# Altium schematic sheet [07] - LOG.SchDoc("[07] - LOG")
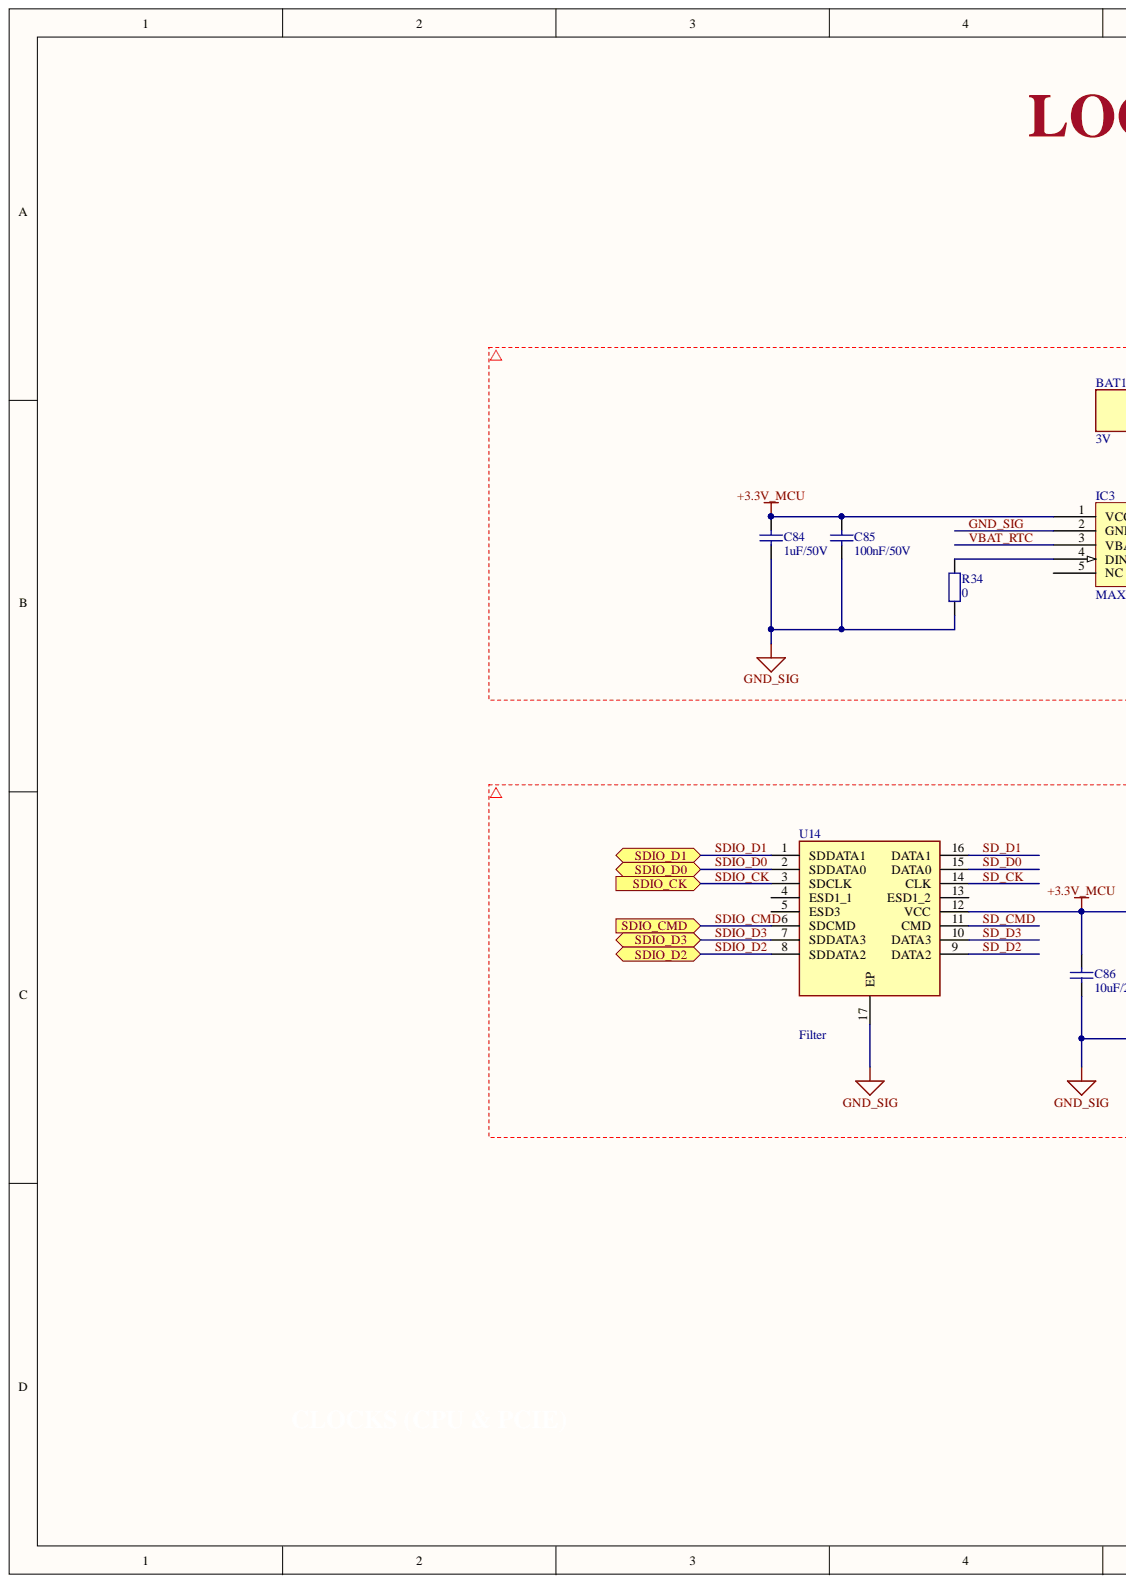
[diagram p0: [07] - LOG.SchDoc, left]
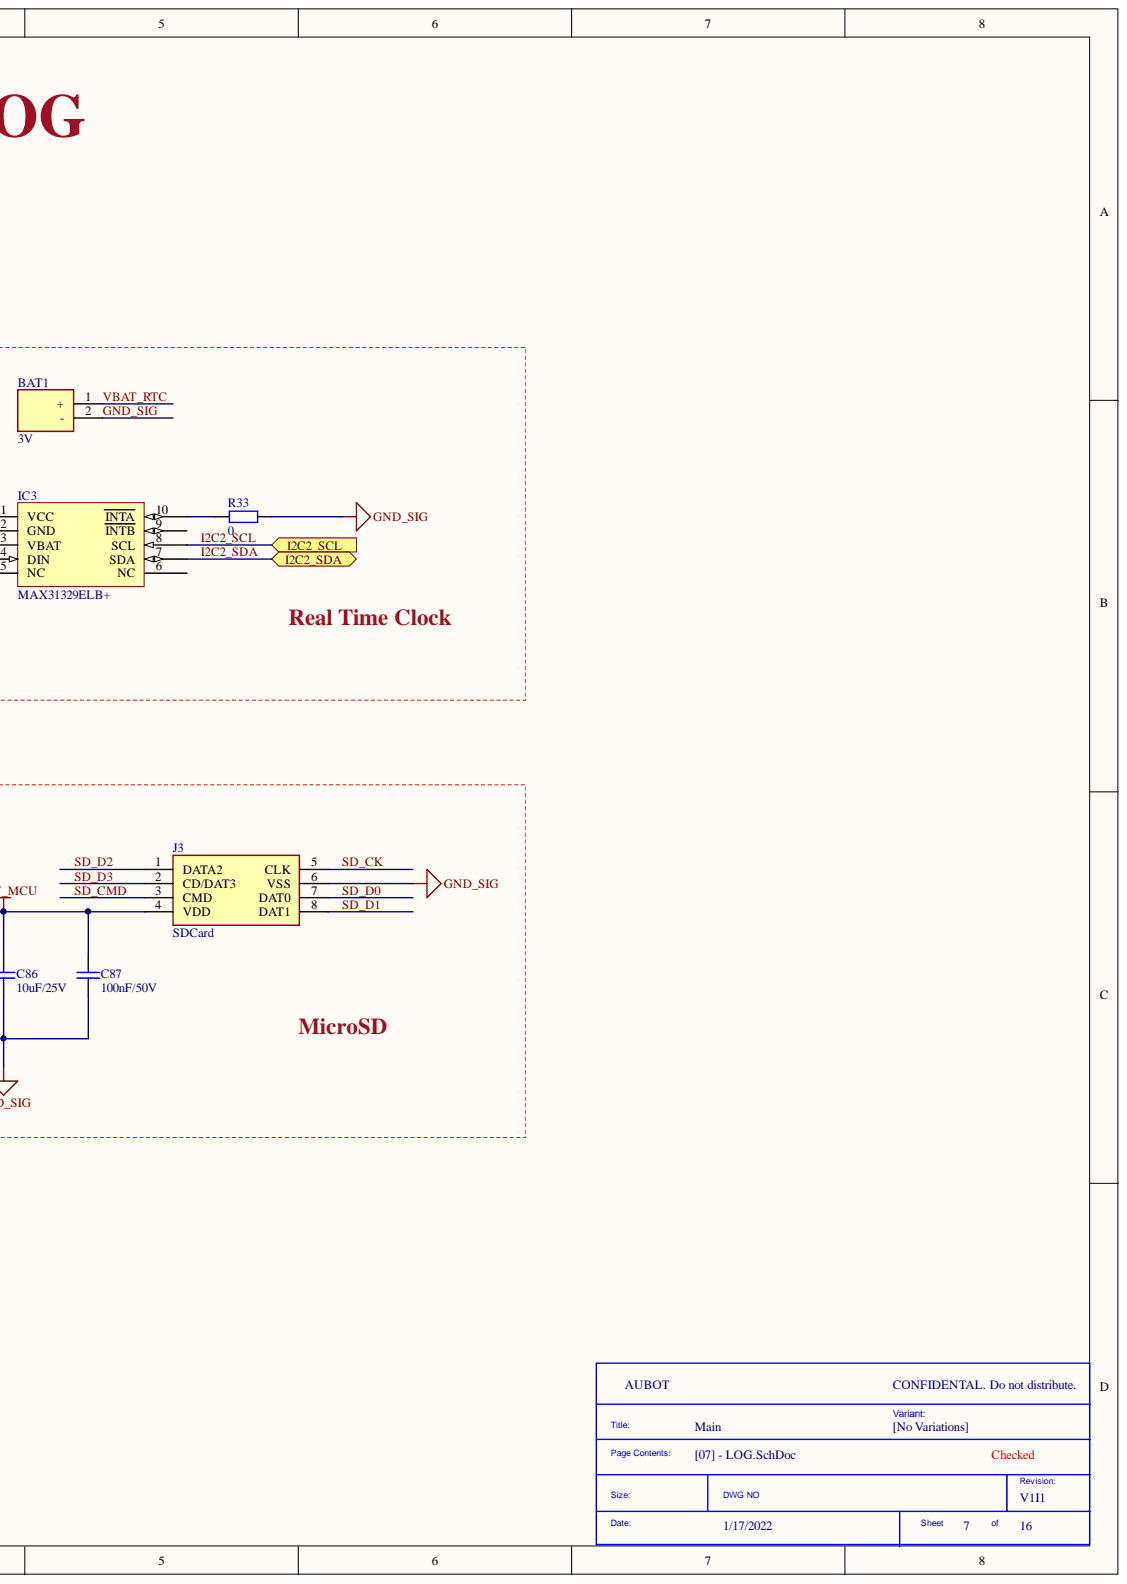
[diagram p1: [07] - LOG.SchDoc, right]

## Parts
BAT1  3V  [SMT CR1220]
C84  1uF/50V  [CAP 0805]
C85  100nF/50V  [CAP 0805]
C86  10uF/25V  [CAP 0805]
C87  100nF/50V  [CAP 0805]
IC3  MAX31329ELB+  [L1055M+2C]
J3  SDCard  [SDCard 8P]
R33  0  [RES 0805]
R34  0  [RES 0805]
U14  Filter  [UDFN-16]

## Ports
I2C2_SCL, I2C2_SDA, SDIO_CK, SDIO_CMD, SDIO_D0, SDIO_D1, SDIO_D2, SDIO_D3

## Nets
+3.3V_MCU: C84.2 C85.2 C86.2 C87.2 IC3.1(VCC) J3.4(VDD) U14.12(VCC)
GND_SIG: BAT1.2 C84.1 C85.1 C86.1 C87.1 IC3.2(GND) J3.6(VSS) R33.2 R34.1 U14.17(EP)
I2C2_SCL: IC3.8(SCL) ; port I2C2_SCL
I2C2_SDA: IC3.7(SDA) ; port I2C2_SDA
SD_CK: J3.5(CLK) U14.14(CLK)
SD_CMD: J3.3(CMD) U14.11(CMD)
SD_D0: J3.7(DAT0) U14.15(DATA0)
SD_D1: J3.8(DAT1) U14.16(DATA1)
SD_D2: J3.1(DATA2) U14.9(DATA2)
SD_D3: J3.2(CD/DAT3) U14.10(DATA3)
SDIO_CK: U14.3(SDCLK) ; port SDIO_CK
SDIO_CMD: U14.6(SDCMD) ; port SDIO_CMD
SDIO_D0: U14.2(SDDATA0) ; port SDIO_D0
SDIO_D1: U14.1(SDDATA1) ; port SDIO_D1
SDIO_D2: U14.8(SDDATA2) ; port SDIO_D2
SDIO_D3: U14.7(SDDATA3) ; port SDIO_D3
VBAT_RTC: BAT1.1 IC3.3(VBAT)
NetIC3_4: IC3.4(DIN) R34.2
NetIC3_10: IC3.10(INTA) R33.1

Not connected: IC3 5(NC) 6(NC) 9(INTB); U14 4(ESD1_1) 5(ESD3) 13(ESD1_2)

## Library data of the parts
BAT1 = Battery 3V: EZ-Coin Cell Battery Retainer, Horizontal SMT, for CR1220 Coin Cell Batteries; Manufacturer Part Number: S8411-45R; Manufacturer: Harwin; Number of Cells: 1; Termination: SMD/SMT; Supplier Part Number 1: 855-S8411-45R; Supplier 1: Mouser; Stock 1: 5083; RoHS: Yes; REACH SVHC: No SVHC; Pricing 1: 1 = 1.52000, 10 = 1.20000, 50 = 1.20000, 100 = 1.02000, 500 = 0.93100, 1000 = 0.85400, 2500 = 0.77500, 5000 = 0.71000, 10000 = 0.70500, 25000 = 0.66500 (USD); Packaging: Tape and Reel; Package Quantity: 500; Number of Batteries: 1; Mount: Surface Mount,PCB; Min Operating Temperature: -55°C; Max Operating Temperature: 105°C; Material: Polyphenylene; Contact Plating: Bronze; ComponentLink2URL: https://datasheet.ciiva.com/1391/1633586-1391067.pdf?src-supplier=Element14; ComponentLink2Description: https://datasheet.ciiva.com/1391/1633586-1391067.pdf?src-supplier=Element14; ComponentLink1URL: https://datasheet.ciiva.com/5224/s8411r-51460-5224679.pdf?src-supplier=Mouser; ComponentLink1Description: https://datasheet.ciiva.com/5224/s8411r-51460-5224679.pdf?src-supplier=Mouser; Component Kind: Standard; Case/Package: SMD/SMT; Library Name: Hieu_Lib.IntLib
C84 = Cap 0805 1uF/50V: 0805 1 uF 50 V ±10 % Tolerance X7R SMT Multilayer Ceramic Capacitor; Manufacturer Part Number: CC0805KKX7R9BB105; Manufacturer: Yageo; Voltage Rating (DC): 50V; Tolerance: 10%; Capacitance: 1uF; Width: 0.049inch; Weight: 0.000194oz; Voltage Rating: 50V; Thickness: 0.057inch; Termination: SMD/SMT; Supplier Part Number 1: 311-1886-1-ND; Supplier 1: Digi-Key; Stock 1: 174238; Series: CC; RoHS Compliant: Yes; RoHS: Yes; Resistor Type: Ceramic; Radiation Hardening: No; Pricing 1: 1 = 0.26000, 10 = 0.17900, 50 = 0.11920, 100 = 0.10130, 500 = 0.07152, 1000 = 0.06257 (USD); Packaging: Tape and Reel; Mount: Surface Mount; Min Operating Temperature: -55°C; Max Operating Temperature: 125°C; Length: 2mm; Lead Free: Lead Free; Height: 1.25mm; Halogen Free: Halogen Free; Dielectric: X7R; Depth: 1.25mm; Construction: Flat; ComponentLink1URL: https://datasheet.ciiva.com/13209/upy-gphc-x7r-6-3v-to-50v-8-12304-13209375.pdf?src-supplier=Mouser; ComponentLink1Description: https://datasheet.ciiva.com/13209/upy-gphc-x7r-6-3v-to-50v-8-12304-13209375.pdf?src-supplier=Mouser; Component Kind: Standard; Case Code (Metric): 2012; Case Code (Imperial): 0805; Case/Package: 0805; Library Name: Hieu_Lib.IntLib
C85 C87 = Cap 0805 100nF/50V: Ceramic capacitor SMD-0805 100nF /50V X7R# K +-10%, Samsung, RoHS; Manufacturer Part Number: CL21B104KBCNNNC; Voltage Rating (DC): 50V; Tolerance: 10%; Dielectric: X7R; Width: 0.049inch; Voltage Rating: 50V; Voltage: 50V; Thickness: 0.037inch; Supplier Part Number 1: C1711; Supplier 1: LCSC; Stock 1: 447650; Series: CL; RoHS Compliant: Yes; RoHS: Yes; Radiation Hardening: No; Pricing 1: 50 = 0.04090, 500 = 0.03130, 1500 = 0.02890, 5000 = 0.02580, 25000 = 0.02430, 50000 = 0.02410, 50 = 0.03950, 500 = 0.03020, 1500 = 0.02790, 5000 = 0.02490, 25000 = 0.02350, 50000 = 0.02330, 50 = 0.20680, 500 = 0.15820, 1500 = 0.14600, 5000 = 0.13020, 25000 = 0.12290, 50000 = 0.12170, 50 = 0.19640, 500 = 0.15020, 1500 = 0.13860, 5000 = 0.12360, 25000 = 0.11670, 50000 = 0.11550, 50 = 0.02640, 500 = 0.02020, 1500 = 0.01860, 5000 = 0.01660, 25000 = 0.01570, 50000 = 0.01550, 50 = 0.02300, 500 = 0.01760, 1500 = 0.01620, 5000 = 0.01440, 25000 = 0.01360, 50000 = 0.01350, 50 = 0.24740, 500 = 0.18920, 1500 = 0.17470, 5000 = 0.15570, 25000 = 0.14700, 50000 = 0.14560, 50 = 3.45220, 500 = 2.64000, 1500 = 2.43700, 5000 = 2.17300, 25000 = 2.05110, 50000 = 2.03080, 50 = 0.13070, 500 = 0.09990, 1500 = 0.09220, 5000 = 0.08230, 25000 = 0.07760, 50000 = 0.07690, 50 = 2.39050, 500 = 1.82810, 1500 = 1.68750, 5000 = 1.50470, 25000 = 1.42030, 50000 = 1.40620, 50 = 0.26740, 500 = 0.20450, 1500 = 0.18870, 5000 = 0.16830, 25000 = 0.15890, 50000 = 0.15730, 50 = 0.04230, 500 = 0.03230, 1500 = 0.02990, 5000 = 0.02660, 25000 = 0.02510, 50000 = 0.02490, 50 = 0.03188, 500 = 0.02438, 1500 = 0.02251, 5000 = 0.02007, 25000 = 0.01894, 50000 = 0.01875 (AUD); Packaging: Tape and Reel; Mount: Surface Mount; Min Operating Temperature: -55°C; Max Operating Temperature: 125°C; Material: Ceramic; Manufacturer: Samsung; Length: 2mm; Lead Free: Lead Free; Height: 0.85mm; Depth: 1.25mm; Contact Plating: Tin; Construction: Flat; ComponentLink2URL: https://datasheet.ciiva.com/29199/454152-da-01-en-vs-kondensator-0805-29199814.pdf?src-supplier=Conrad; ComponentLink2Description: https://datasheet.ciiva.com/29199/454152-da-01-en-vs-kondensator-0805-29199814.pdf?src-supplier=Conrad; ComponentLink1URL: https://datasheet.ciiva.com/25710/cl21b104kbcnnnc-25710182.pdf?src-supplier=Digi-Key; ComponentLink1Description: https://datasheet.ciiva.com/25710/cl21b104kbcnnnc-25710182.pdf?src-supplier=Digi-Key; Component Kind: Standard; Case Code (Metric): 2012; Case Code (Imperial): 0805; Case/Package: 0805; Capacitance: 100nF; Library Name: Hieu_Lib.IntLib
C86 = Cap 0805 10uF/25V: 0805 10 uF 25 V ±10 % Tolerance X5R SMT Multilayer Ceramic Capacitor; Manufacturer Part Number: CC0805KKX5R8BB106; Manufacturer: Yageo; Voltage Rating (DC): 25V; Tolerance: 10%; Capacitance: 10uF; Width: 0.049inch; Weight: 0.000194oz; Voltage Rating: 25V; Thickness: 0.057inch; Termination: SMD/SMT; Supplier Part Number 1: 311-1869-1-ND; Supplier 1: Digi-Key; Stock 1: 149609; Series: CC; RoHS Compliant: Yes; RoHS: Yes; Resistor Type: Ceramic; Pricing 1: 1 = 0.55000, 10 = 0.38500, 50 = 0.26960, 100 = 0.23120, 500 = 0.17336, 1000 = 0.14640 (USD); Packaging: Tape and Reel; Mount: Surface Mount; Min Operating Temperature: -55°C; Max Operating Temperature: 85°C; Length: 0.079inch; Lead Free: Lead Free; Halogen Free: Halogen Free; Dielectric: X5R; Depth: 1.25mm; ComponentLink3URL: http://www.yageo.com/documents/recent/UPY-GPHC_X5R_4V-to-50V_25.pdf?src-supplier=Digi-Key; ComponentLink3Description: http://www.yageo.com/documents/recent/UPY-GPHC_X5R_4V-to-50V_25.pdf?src-supplier=Digi-Key; ComponentLink2URL: http://www.mouser.com/ds/2/447/UPY-GPHC_X5R_4V-to-50V_25-1131599.pdf?src-supplier=Mouser; ComponentLink2Description: http://www.mouser.com/ds/2/447/UPY-GPHC_X5R_4V-to-50V_25-1131599.pdf?src-supplier=Mouser; ComponentLink1URL: http://components.arrow.com/part/dataview/0323_04166572?src-supplier=Arrow+Europe; ComponentLink1Description: http://components.arrow.com/part/dataview/0323_04166572?src-supplier=Arrow+Europe; Component Kind: Standard; Case Code (Metric): 2012; Case Code (Imperial): 0805; Case/Package: 0805; Library Name: Hieu_Lib.SchLib
IC3 = MAX31329ELB+: Real Time Clock, -40 to 85DEG C Rohs Compliant: Yes; Manufacturer: Maxim; Supplier Part Number 1: 175-MAX31329ELB+-ND; Supplier 1: Digi-Key; Stock 1: 980; RoHS: No; Pricing 1: 1 = 3.10000, 10 = 2.78500, 25 = 2.63240, 80 = 2.28150, 230 = 2.16452, 490 = 1.94220, 980 = 1.75500 (USD); Manufacturer Part Number: MAX31329ELB+; Component Kind: Standard; Library Name: Hieu_Lib.SchLib
J3 = Conn Micro SD Card: Conn Micro SD Card M 8 POS 1.09mm Solder RA SMD Embossed T/R 0.5A/Contact; Manufacturer Part Number: 503182-1852; Manufacturer: Molex; Pitch: 1.1mm; Number of Circuits: 8; Gender: Male; Connector Type: Connector; Termination: Solder; Supplier Part Number 1: 538-503182-1852; Supplier 1: Mouser; Stock 1: 14079; RoHS Compliant: Yes; RoHS: Yes; REACH SVHC: No SVHC; Pricing 1: 1 = 3.64000, 10 = 3.21000, 50 = 3.21000, 100 = 2.56000, 1000 = 2.12000, 10000 = 1.96000 (USD); Packaging: Tape and Reel; Package Quantity: 1600; Orientation: Right Angle; Number of Rows: 1; Mount: Surface Mount; Min Operating Temperature: -25°C; Max Operating Temperature: 85°C; Length: 15.2mm; Housing Material: Polymer; Halogen Free: Low Halogen; Depth: 14.95mm; Contact Plating: Gold; Contact Material: Copper Alloy; ComponentLink6URL: http://www.farnell.com/datasheets/2701359.pdf?src-supplier=Newark; ComponentLink6Description: http://www.farnell.com/datasheets/2701359.pdf?src-supplier=Newark; ComponentLink5URL: http://www.farnell.com/datasheets/2701359.pdf?src-supplier=Element14; ComponentLink5Description: http://www.farnell.com/datasheets/2701359.pdf?src-supplier=Element14; ComponentLink4URL: https://www.molex.com/pdm_docs/sd/5031821852_sd.pdf?src-supplier=Digi-Key; ComponentLink4Description: https://www.molex.com/pdm_docs/sd/5031821852_sd.pdf?src-supplier=Digi-Key; ComponentLink3URL: http://www.molex.com/pdm_docs/sd/5031821852_sd.pdf?src-supplier=Digi-Key; ComponentLink3Description: http://www.molex.com/pdm_docs/sd/5031821852_sd.pdf?src-supplier=Digi-Key; ComponentLink2URL: http://components.arrow.com/part/dataview/0323_07103516?src-supplier=Arrow+Europe; ComponentLink2Description: http://components.arrow.com/part/dataview/0323_07103516?src-supplier=Arrow+Europe; ComponentLink1URL: http://www.farnell.com/datasheets/2701359.pdf?src-supplier=Farnell; ComponentLink1Description: http://www.farnell.com/datasheets/2701359.pdf?src-supplier=Farnell; Component Kind: Standard; Library Name: Hieu_Lib.IntLib
R33 R34 = Res 0805 0 Ohm 1%: RC Series 0805 0.125 W 0 Ohm Jumper SMT Thick Film Chip Resistor; Manufacturer Part Number: RC0805FR-070RL; Manufacturer: Yageo; Tolerance: 1%; Resistance: 0mR; Power Rating: 125mW; Supplier Part Number 1: 311-0.0CRCT-ND; Supplier 1: Digi-Key; Stock 1: 579408; Size Code: 0805; Series: RC; RoHS Compliant: Yes; RoHS: Yes; Resistor Type: Thick Film; Radiation Hardening: No; Pricing 1: 1 = 0.10000, 10 = 0.03200, 25 = 0.02360, 50 = 0.01780, 100 = 0.01320, 250 = 0.01004, 500 = 0.00802, 1000 = 0.00591 (USD); Packaging: Tape and Reel; Number of Terminations: 2; Min Operating Temperature: -55°C; Military Standard: Not; Max Power Dissipation: 125mW; Max Operating Temperature: 155°C; Length: 2mm; Lead Free: Lead Free; Features: Moisture Resistant; Depth: 1.25mm; Contact Plating: Tin,Matte; Construction: Rectangular; ComponentLink2URL: https://datasheet.ciiva.com/15189/getdatasheetpartid-348154-15189885.pdf?src-supplier=Verical; ComponentLink2Description: https://datasheet.ciiva.com/15189/getdatasheetpartid-348154-15189885.pdf?src-supplier=Verical; ComponentLink1URL: https://datasheet.ciiva.com/17042/rc0805-17042698.pdf?src-supplier=Future+Electronics; ComponentLink1Description: https://datasheet.ciiva.com/17042/rc0805-17042698.pdf?src-supplier=Future+Electronics; Component Kind: Standard; Case Code (Metric): 2012; Case Code (Imperial): 0805; Case/Package: 0805; Library Name: Hieu_Lib.IntLib
U14 = Filter Circuits 8-CH MicroSD: CM1624: Praetorian III¬ EMI Filter Device with ESD Protection for T-Flash/MicroSD Interfaces; Manufacturer Part Number: CM1624-08DE; Manufacturer: ON Semiconductor; Operating Supply Voltage: 5V; Number of Lines: 8; Number of Channels: 6; ESD Protection: Yes; Direction: Bidirectional; DC Resistance (DCR): 40R; Clamping Voltage-Max: 9V; Capacitance: 20pF; Width: 1.35mm; Termination: Flat; Supplier Part Number 1: 748-CM1624-08DE; Supplier 1: Mouser; Stock 1: 10687; Series: Praetorian III®; RoHS Compliant: Yes; RoHS: Yes; REACH SVHC: No SVHC; Pricing 1: 1 = 0.62000, 10 = 0.55100, 25 = 0.51800, 50 = 0.51800, 100 = 0.38300, 250 = 0.33400, 500 = 0.31700, 1000 = 0.25200, 3000 = 0.24300, 10000 = 0.24300 (USD); Packaging: Tape and Reel; Package Quantity: 1; Number of Pins: 16; Min Operating Temperature: -40°C; Max Operating Temperature: 85°C; Length: 3.4mm; Lead Free: Lead Free; Height: 0.022inch; Halogen Free: Halogen Free; Depth: 1.45mm; Contact Plating: Tin,Matte; ComponentLink9URL: https://datasheet.ciiva.com/15225/getdatasheetpartid-650344-15225530.pdf?src-supplier=Verical; ComponentLink9Description: https://datasheet.ciiva.com/15225/getdatasheetpartid-650344-15225530.pdf?src-supplier=Verical; ComponentLink8URL: https://datasheet.ciiva.com/1022/cm1624-d-1022810.pdf?src-supplier=Digi-Key; ComponentLink8Description: https://datasheet.ciiva.com/1022/cm1624-d-1022810.pdf?src-supplier=Digi-Key; ComponentLink7URL: https://datasheet.ciiva.com/1022/cm1624-d-1022809.pdf?src-supplier=Digi-Key; ComponentLink7Description: https://datasheet.ciiva.com/1022/cm1624-d-1022809.pdf?src-supplier=Digi-Key; ComponentLink6URL: https://datasheet.ciiva.com/1022/cm1624-d-1022808.pdf?src-supplier=Digi-Key; ComponentLink6Description: https://datasheet.ciiva.com/1022/cm1624-d-1022808.pdf?src-supplier=Digi-Key; ComponentLink5URL: https://datasheet.ciiva.com/3067/cm1624-d-3067221.pdf?src-supplier=Avnet; ComponentLink5Description: https://datasheet.ciiva.com/3067/cm1624-d-3067221.pdf?src-supplier=Avnet; ComponentLink4URL: https://datasheet.ciiva.com/3067/cm1624-d-3067220.pdf?src-supplier=Avnet; ComponentLink4Description: https://datasheet.ciiva.com/3067/cm1624-d-3067220.pdf?src-supplier=Avnet; ComponentLink3URL: https://datasheet.ciiva.com/26793/cm1624-08de-26793355.pdf?src-supplier=IIIC; ComponentLink3Description: https://datasheet.ciiva.com/26793/cm1624-08de-26793355.pdf?src-supplier=IIIC; ComponentLink2URL: https://datasheet.ciiva.com/4942/cm1624-d-92596-4942166.pdf?src-supplier=Mouser; ComponentLink2Description: https://datasheet.ciiva.com/4942/cm1624-d-92596-4942166.pdf?src-supplier=Mouser; ComponentLink1URL: https://datasheet.ciiva.com/1267/cm1624-d-1267908.pdf?src-supplier=Element14; ComponentLink1Description: https://datasheet.ciiva.com/1267/cm1624-d-1267908.pdf?src-supplier=Element14; Component Kind: Standard; Clamping Voltage: 9V; Case/Package: DFN; Library Name: Hieu_Lib.IntLib
